# Altium schematic sheet [4]-GPS,3G.SchDoc("[4]-GPS,3G")
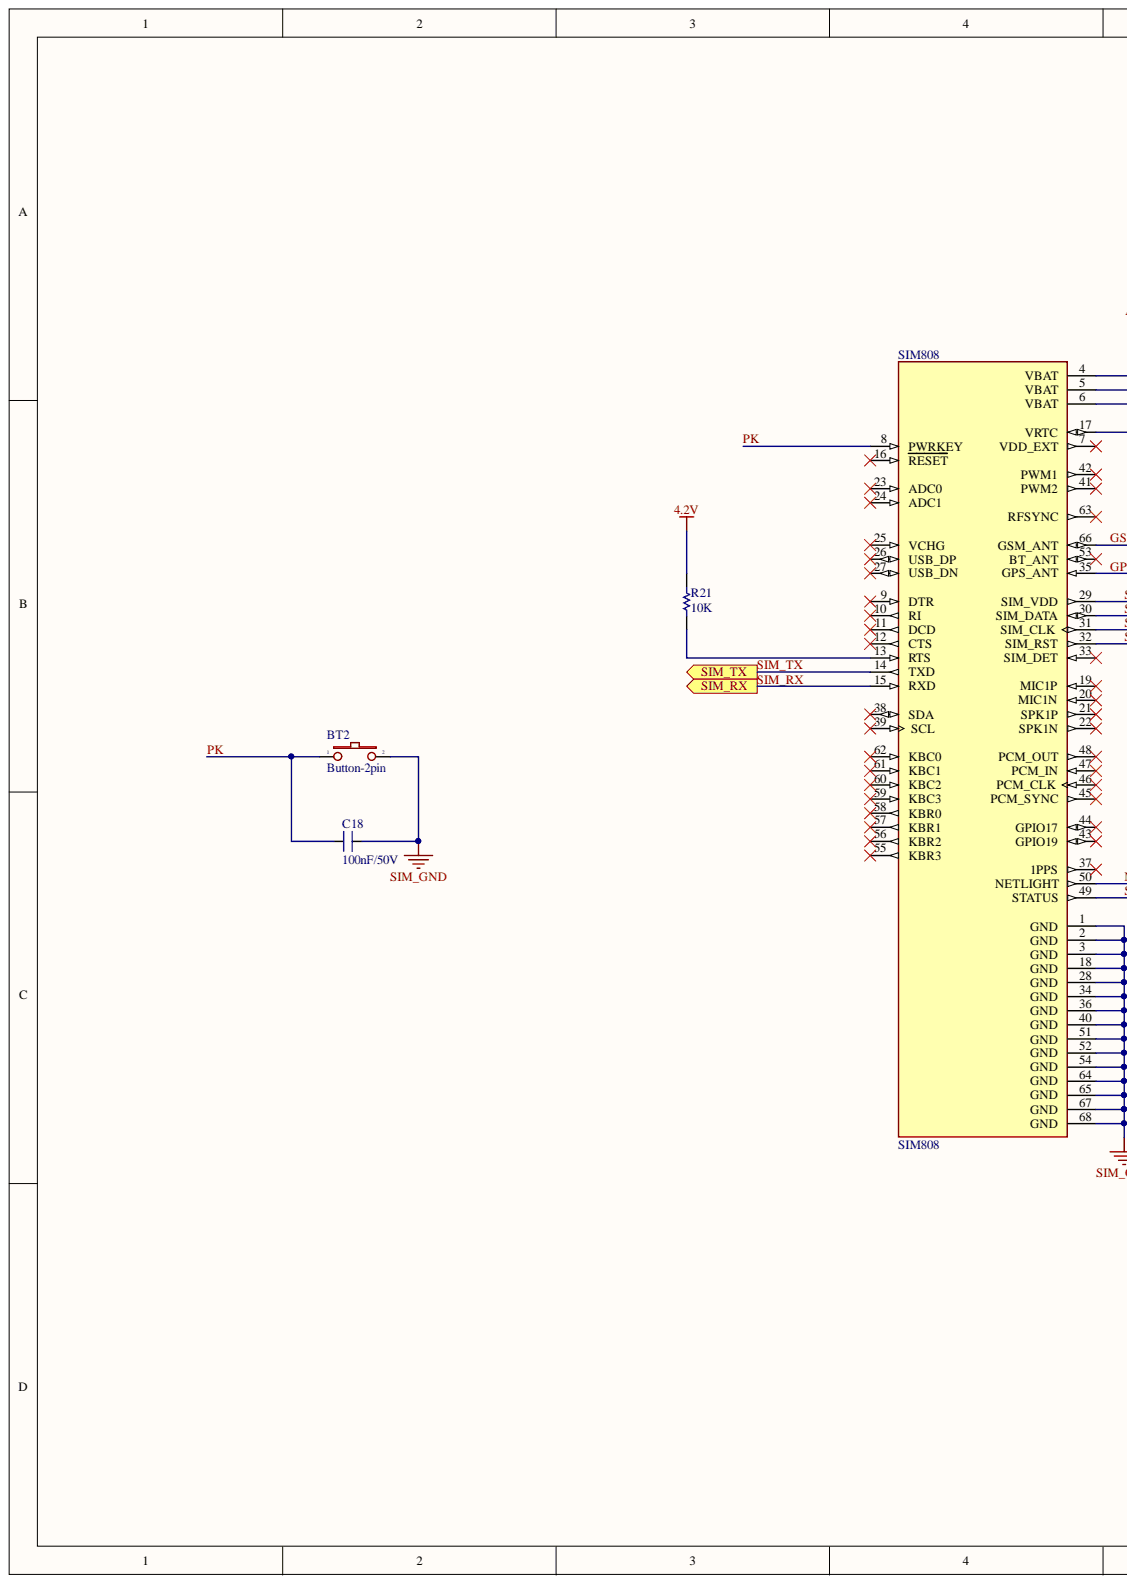
[diagram p0: [4]-GPS,3G.SchDoc, left]
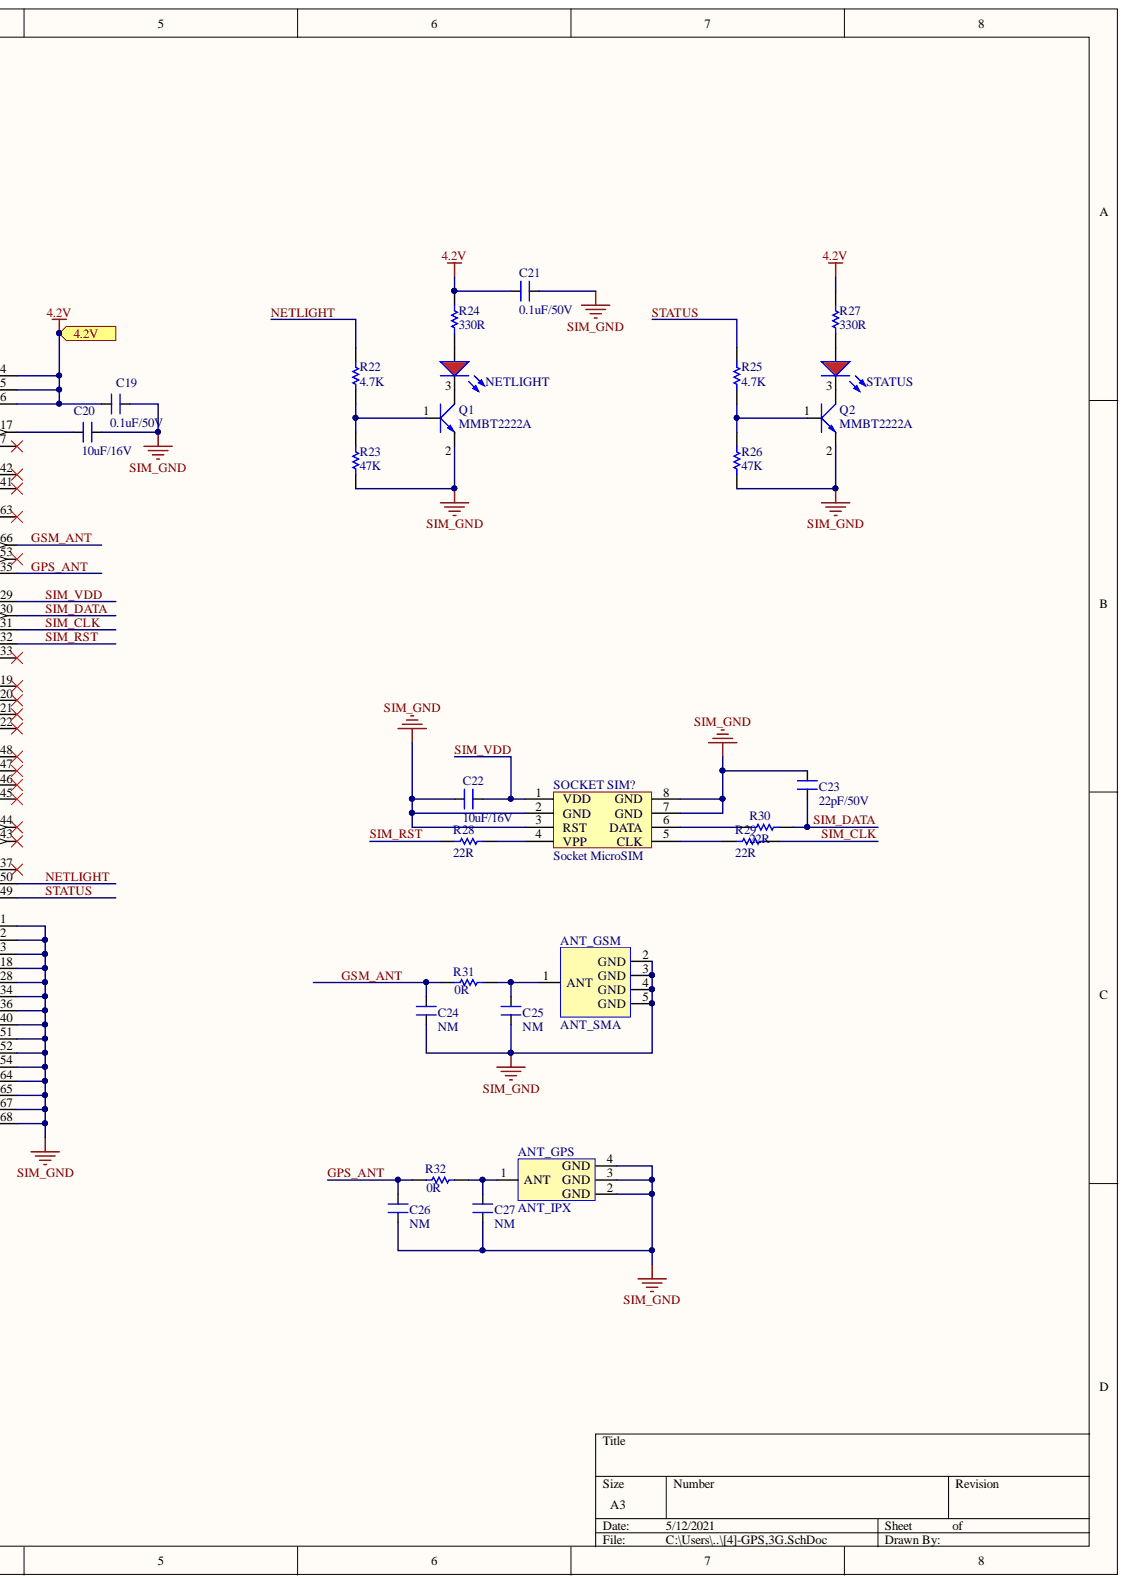
[diagram p1: [4]-GPS,3G.SchDoc, right]

## Parts
ANT_GPS  ANT_IPX  [ANT-IPX-SMD]
ANT_GSM  ANT_SMA  [ANT-SMA-ST-F]
BT2  Button-2pin  [BUTTON_2P_SMD]
C18  100nF/50V  [CAP 0603(1508)]
C19  0.1uF/50V  [CAP 0603(1508)]
C20  10uF/16V  [CAP 0603(1508)]
C21  0.1uF/50V  [CAP 0603(1508)]
C22  10uF/16V  [CAP 0603(1508)]
C23  22pF/50V  [CAP 0603(1508)]
C24  NM  [CAP 0603(1508)]
C25  NM  [CAP 0603(1508)]
C26  NM  [CAP 0603(1508)]
C27  NM  [CAP 0603(1508)]
NETLIGHT  LED_RED  [LED0805RED]  (value not drawn)
Q1  MMBT2222A  [MMBT2222a]
Q2  MMBT2222A  [MMBT2222a]
R21  10K  [RES 0603(1608)]
R22  4.7K  [RES 0603(1608)]
R23  47K  [RES 0603(1608)]
R24  330R  [RES 0603(1608)]
R25  4.7K  [RES 0603(1608)]
R26  47K  [RES 0603(1608)]
R27  330R  [RES 0603(1608)]
R28  22R  [RES 0603(1608)]
R29  22R  [RES 0603(1608)]
R30  22R  [RES 0603(1608)]
R31  0R  [RES 0603(1608)]
R32  0R  [RES 0603(1608)]
SIM808  SIM808  [XCVR_SIM808]
SOCKET_SIM?  Socket MicroSIM  [Socket MicroSIM 6P]
STATUS  LED_RED  [LED0805RED]  (value not drawn)

## Ports
4.2V, SIM_RX, SIM_TX

## Nets
SIM_GND: ANT_GPS.2(GND) ANT_GPS.3(GND) ANT_GPS.4(GND) ANT_GSM.2(GND) ANT_GSM.3(GND) ANT_GSM.4(GND) ANT_GSM.5(GND) BT2.2 C18.2 C19.2 C20.2 C21.2 C22.1 C23.2 C24.1 C25.1 C26.1 C27.1 Q1.2 Q2.2 R23.2 R26.2 SIM808.1(GND) SIM808.2(GND) SIM808.3(GND) SIM808.18(GND) SIM808.28(GND) SIM808.34(GND) SIM808.36(GND) SIM808.40(GND) SIM808.51(GND) SIM808.52(GND) SIM808.54(GND) SIM808.64(GND) SIM808.65(GND) SIM808.67(GND) SIM808.68(GND) SOCKET_SIM?.2(GND) SOCKET_SIM?.3(RST) SOCKET_SIM?.7(GND) SOCKET_SIM?.8(GND)
4.2V: C19.1 C21.1 R21.1 R24.1 R27.1 SIM808.4(VBAT) SIM808.5(VBAT) SIM808.6(VBAT) ; port 4.2V
GPS_ANT: C26.2 R32.2 SIM808.35(GPS_ANT)
GSM_ANT: C24.2 R31.2 SIM808.66(GSM_ANT)
NETLIGHT: R22.1 SIM808.50(NETLIGHT)
PK: BT2.1 C18.1 SIM808.8(PWRKEY)
SIM_CLK: R29.1 SIM808.31(SIM_CLK)
SIM_DATA: C23.1 R30.1 SIM808.30(SIM_DATA)
SIM_RST: R28.2 SIM808.32(SIM_RST)
SIM_RX: SIM808.15(RXD) ; port SIM_RX
SIM_TX: SIM808.14(TXD) ; port SIM_TX
SIM_VDD: C22.2 SIM808.29(SIM_VDD) SOCKET_SIM?.1(VDD)
STATUS: R25.1 SIM808.49(STATUS)
NetANT_GPS_1: ANT_GPS.1(ANT) C27.2 R32.1
NetANT_GSM_1: ANT_GSM.1(ANT) C25.2 R31.1
NetC20_1: C20.1 SIM808.17(VRTC)
NetNETLIGHT_1: NETLIGHT.1 R24.2
NetNETLIGHT_2: NETLIGHT.2 Q1.3
NetQ1_1: Q1.1 R22.2 R23.1
NetQ2_1: Q2.1 R25.2 R26.1
NetQ2_3: Q2.3 STATUS.2
NetR21_2: R21.2 SIM808.13(RTS)
NetR27_2: R27.2 STATUS.1
NetR28_1: R28.1 SOCKET_SIM?.4(VPP)
NetR29_2: R29.2 SOCKET_SIM?.5(CLK)
NetR30_2: R30.2 SOCKET_SIM?.6(DATA)

Not connected: SIM808 7(VDD_EXT) 9(DTR) 10(RI) 11(DCD) 12(CTS) 16(RESET) 19(MIC1P) 20(MIC1N) 21(SPK1P) 22(SPK1N) 23(ADC0) 24(ADC1) 25(VCHG) 26(USB_DP) 27(USB_DN) 33(SIM_DET) 37(1PPS) 38(SDA) 39(SCL) 41(PWM2) 42(PWM1) 43(GPIO19) 44(GPIO17) 45(PCM_SYNC) 46(PCM_CLK) 47(PCM_IN) 48(PCM_OUT) 53(BT_ANT) 55(KBR3) 56(KBR2) 57(KBR1) 58(KBR0) 59(KBC3) 60(KBC2) 61(KBC1) 62(KBC0) 63(RFSYNC)

## Library data of the parts
C18 = CAP_CER_100nF_50V_NPOL: Ceramic Capacitor 100nF/50V (+-20% TH)/(+10%SMD); Component Kind: Standard; Library Name: Duc.SchLib
C19 C21 C24-C27 = CAP_CER_0.1uF_50V_NPOL_1: Ceramic Capacitor 1nF/50V (+-20% TH)/(+10%SMD); Component Kind: Standard; Library Name: Duc.SchLib
C20 C22 = CAP_CER_10uF_16V_NPOL: Ceramic Capacitor 10uF/16V (+-10% TH)/(+10%SMD); Component Kind: Standard; : 10uF/35V; Library Name: Duc.SchLib
C23 = CAP_CER_22pF_50V_NPOL: Ceramic Capacitor 22pF/50V (+-10% TH)/(+5%SMD); Component Kind: Standard; Library Name: Duc.SchLib
NETLIGHT STATUS = LED_RED: LED Red; Value: Red; Unit Price: 0.0476; ComponentLink1Description: Datasheet; Component Kind: Standard; Library Name: Duc.SchLib; ComponentLink1URL: D:\Dropbox\Datasheets\Opto and Illumination\LEDs\SMD LED.pdf
R21-R32 = Resistor 0603: Description: Resistor 0603; Component Kind: Standard; Library Name: Duc.SchLib
SIM808 = SIM808: SIM808 GPS, GPRS/GSM RF Arduino Platform Evaluation Expansion Board; MF: Adafruit Industries; SnapEDA_Link: https://www.snapeda.com/parts/SIM808/SIMcom%20Wireless%20solutions%20Co.%2CLtd/view-part/424834/?ref=snap; Price: None; Package: None; MP: SIM808; Library Name: SIM808 (5).IntLib; Component Kind: Standard; Availability: Unavailable
ANT_GPS = ANT_IPX: Component Kind: Standard; Library Name: Duc.SchLib
ANT_GSM = ANT_SMA: Component Kind: Standard; Library Name: Duc.SchLib
BT2 = Button-2pin: Component Kind: Standard; Library Name: Duc.SchLib
Q1 Q2 = MMBT2222A: Component Kind: Standard; Library Name: Duc.SchLib
SOCKET_SIM? = Socket MicroSIM: Description: Socket MicroSIM; Component Kind: Standard; Library Name: Duc.SchLib
